# Altium schematic sheet cw312_xc7a35t_cardedge.SchDoc
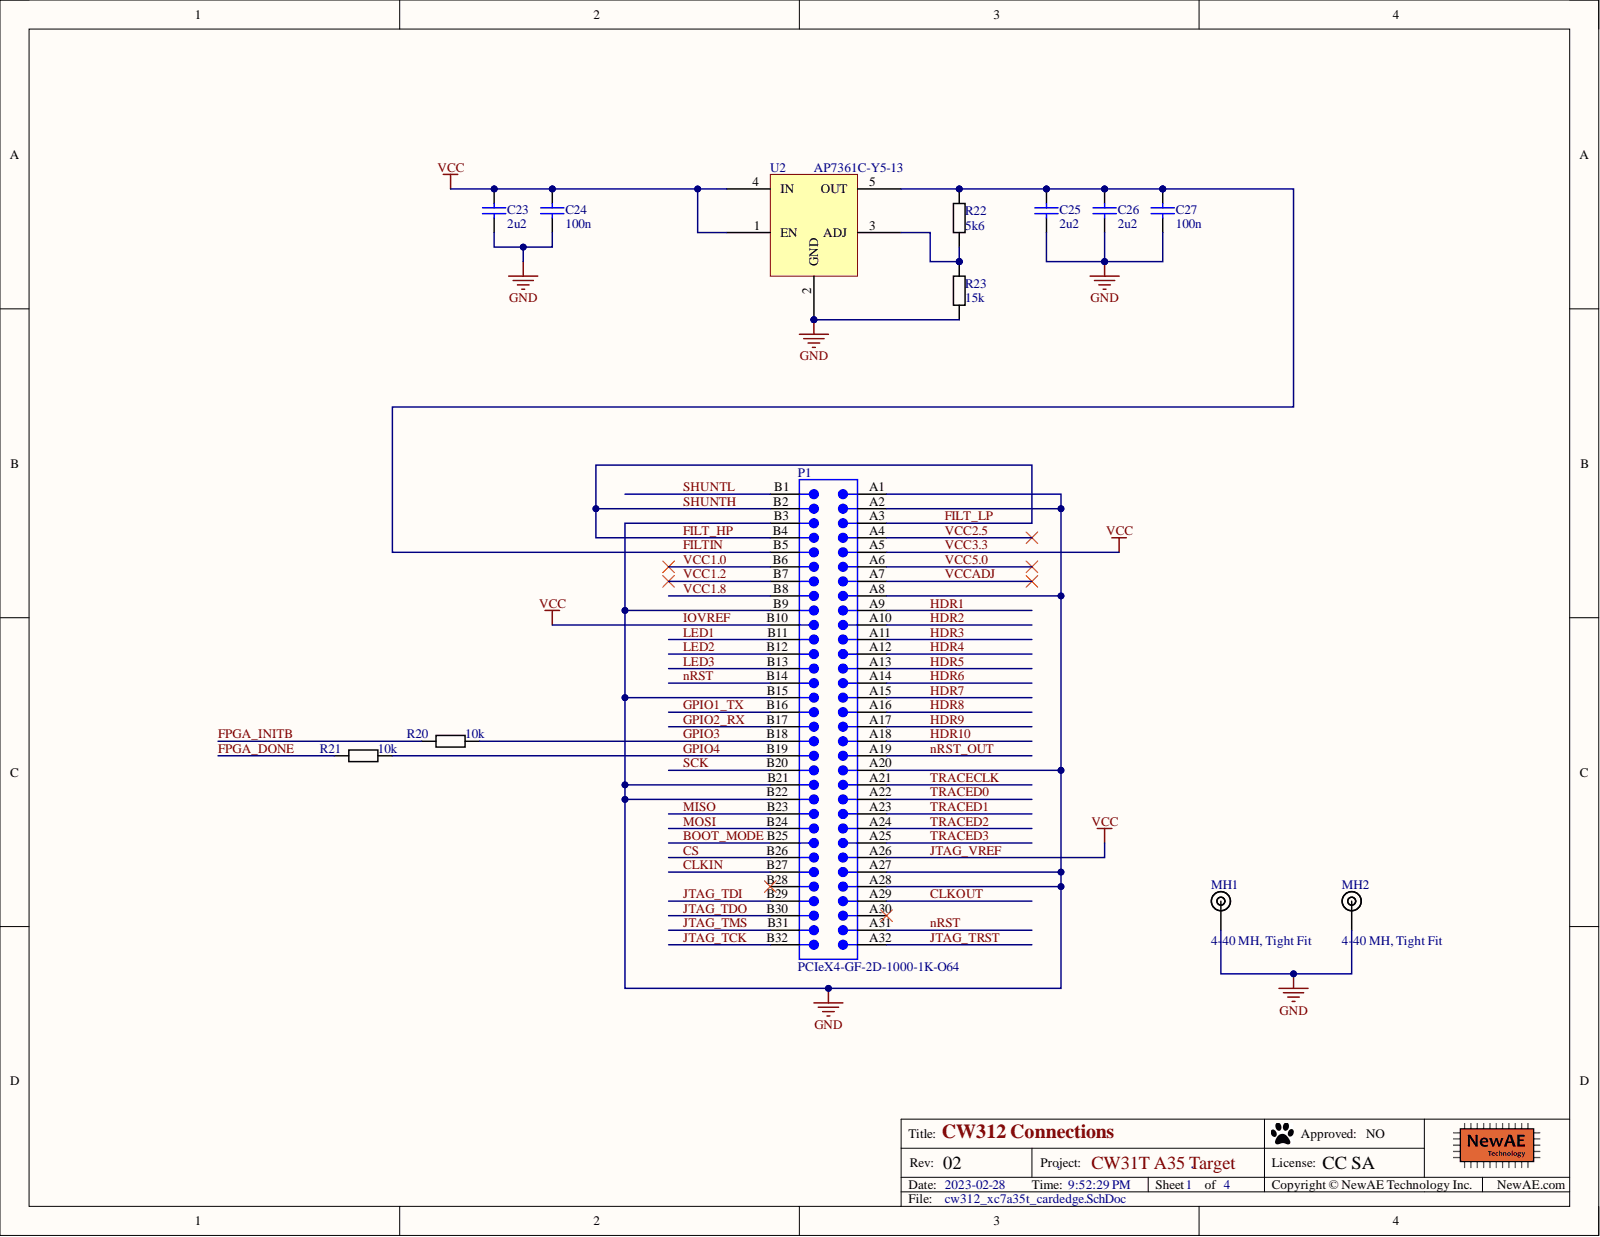
[diagram p0: cw312_xc7a35t_cardedge.SchDoc]

## Parts
C23  2u2  [0603]
C24  100n  [0402]
C25  2u2  [0603]
C26  2u2  [0603]
C27  100n  [0402]
MH1  4-40 MH, Tight Fit  [MOUNT_#4_TIGHT]  (mechanical)
MH2  4-40 MH, Tight Fit  [MOUNT_#4_TIGHT]  (mechanical)
P1  PCIeX4-GF-2D-1000-1K-O64  [PCIeX4-GF-2D-1000-1K-O64]  (no BOM)
R20  10k  [0603]
R21  10k  [0603]
R22  5k6  [0603]
R23  15k  [0603]
U2  AP7361C-Y5-13  [SOT89-5]

## Nets
GND: C23.2 C24.2 C25.2 C26.2 C27.2 MH1.1 MH2.1 P1.A1 P1.A2 P1.A8 P1.A20 P1.A27 P1.A28 P1.B3 P1.B9 P1.B15 P1.B21 P1.B22 R23.2 U2.2(GND)
BOOT_MODE: P1.B25
CLKIN: P1.B27
CLKOUT: P1.A29
CS: P1.B26
FILT_HP: P1.A3 P1.B2 P1.B4
FILTIN: C25.1 C26.1 C27.1 P1.B5 R22.1 U2.5(OUT)
FPGA_DONE: R21.1
FPGA_INITB: R20.1
GPIO1_TX: P1.B16
GPIO2_RX: P1.B17
GPIO3: P1.B18 R20.2
GPIO4: P1.B19 R21.2
HDR1: P1.A9
HDR2: P1.A10
HDR3: P1.A11
HDR4: P1.A12
HDR5: P1.A13
HDR6: P1.A14
HDR7: P1.A15
HDR8: P1.A16
HDR9: P1.A17
HDR10: P1.A18
IOVREF: C23.1 C24.1 P1.A5 P1.A26 P1.B10 U2.1(EN) U2.4(IN)
JTAG_TCK: P1.B32
JTAG_TDI: P1.B29
JTAG_TDO: P1.B30
JTAG_TMS: P1.B31
JTAG_TRST: P1.A32
LED1: P1.B11
LED2: P1.B12
LED3: P1.B13
MISO: P1.B23
MOSI: P1.B24
nRST: P1.A31 P1.B14
nRST_OUT: P1.A19
SCK: P1.B20
SHUNTL: P1.B1
TRACECLK: P1.A21
TRACED0: P1.A22
TRACED1: P1.A23
TRACED2: P1.A24
TRACED3: P1.A25
VCC1.0: P1.B6
VCC1.2: P1.B7
VCC1.8: P1.B8
VCC2.5: P1.A4
VCC5.0: P1.A6
VCCADJ: P1.A7
NetR22_2: R22.2 R23.1 U2.3(ADJ)

Not connected: P1 A30 B28

## Library data of the parts
C23 C25 C26 = CCHIP-2u2-0603: 2u2, 0603; Manufacture 1: Samsung; Manufacture Part Number 1: CL10B225KP8NNNC; Case-Metric: 1608; Component Group: Capacitor; Database Table Name: main; Rated Voltage: 10VDC; Case-EIA: 0603; Component Kind: Standard; Component Type: Standard; Library Name: NewAE_AltiumLib.DBLib; Mounting Technology: SMT; Part Number: CCHIP-2u2-0603; Pin Count: 2; Supplier 1: Digi-Key; Supplier Part Number 1: 1276-1134-1-ND; Value: 2u2
C24 C27 = CCHIP-100n-0402: 100n, 0402; Manufacture Part Number 1: CGA2B3X7R1V104K050BB; Component Group: Capacitor; Database Table Name: main; Case-EIA: 0402; Component Kind: Standard; Component Type: Standard; Library Name: NewAE_AltiumLib.DBLib; Mounting Technology: SMT; Part Number: CCHIP-100n-0402; Pin Count: 2; Supplier 1: Digi-Key; Supplier Part Number 1: 445-6901-1-ND; Value: 100n
MH1 MH2 = MOUNT_#4_TIGHT: Machine screw 4-40 Mounting Hole; Component Group: Mechanical; Database Table Name: main; PP Material Stack: 0; Component Type: Mechanical; Library Name: NewAE_AltiumLib.DBLib; Part Number: MOUNT_#4_TIGHT; Pin Count: 1; PP Rotation: 0
P1 = CMP-0001-00031-2: Golden finger, PCIeX4, Dual, Opposite, Pitch 1mm, 64-Position; Mating Connector: Molex-87715-9106; ClassName: Edge Connector; Datasheet Version: rev2.0, Apr-2007; Library Name: Altium Content Vault; Package Reference: PCIeX4-GF-2D-1000-1K-O64; Publisher: Altium
R20 R21 = RCHIP-10K-0603: 10k res, 0603, 1%, 1/10W; Manufacture 1: Yageo; Manufacture Part Number 1: RC0603FR-0710KL; Case-Metric: 1608; Component Group: Resistor; Database Table Name: main; Rated Power: 0.1W; Rated Voltage: 75VDC; Case-EIA: 0603; Component Kind: Standard; Component Type: Standard; Library Name: NewAE_AltiumLib.DBLib; Mounting Technology: SMT; Part Number: RCHIP-10K-0603; Pin Count: 2; Supplier 1: Digi-Key; Supplier Part Number 1: 311-10.0KHRCT-ND; Temperature Range: -55C to 155C; Tolerance: 1%; Value: 10k
R22 = RCHIP-5K6-0603: 5k6 res, 0603, 1%, 1/10W; Manufacture 1: Yageo; Manufacture Part Number 1: RC0603FR-075K6L; Case-Metric: 1608; Component Group: Resistor; Database Table Name: main; Rated Power: 0.1W; Rated Voltage: 75VDC; Case-EIA: 0603; Component Kind: Standard; Component Type: Standard; Library Name: NewAE_AltiumLib.DBLib; Mounting Technology: SMT; Part Number: RCHIP-5K6-0603; Pin Count: 2; Supplier 1: Digi-Key; Supplier Part Number 1: 311-5.60KHRCT-ND; Temperature Range: -55C to 155C; Tolerance: 1%; Value: 5k6
R23 = RCHIP-15k-0603: 15k res, 0603, 1%, 1/10W; Manufacture 1: Yageo; Manufacture Part Number 1: RC0603FR-0715KL; Case-Metric: 1608; Component Group: Resistor; Database Table Name: main; Rated Power: 0.1W; Rated Voltage: 75VDC; Case-EIA: 0603; Component Kind: Standard; Component Type: Standard; Library Name: NewAE_AltiumLib.DBLib; Mounting Technology: SMT; Part Number: RCHIP-15K-0603; Pin Count: 2; Supplier 1: Digi-Key; Supplier Part Number 1: 311-15.0KHRCT-ND; Temperature Range: -55C to 155C; Tolerance: 1%; Value: 15k
U2 = AP7361C-Y5-13: Regulator, Adj, LDO, 1A; Manufacture 1: Diodes Inc; Component Group: Analog IC; Database Table Name: main; PP Material Stack: 0; Component Kind: Standard; Component Type: Standard; Library Name: NewAE_AltiumLib.DBLib; Manufacture Part Number 1: AP7361C-Y5-13; Part Number: AP7361C-Y5-13; Pin Count: 5; PP Rotation: 0; PP Rotation1: 0; Supplier 1: Digi-Key; Supplier Part Number 1: AP7361C-Y5-13DICT-ND
